# Altium schematic sheet Top.SchDoc
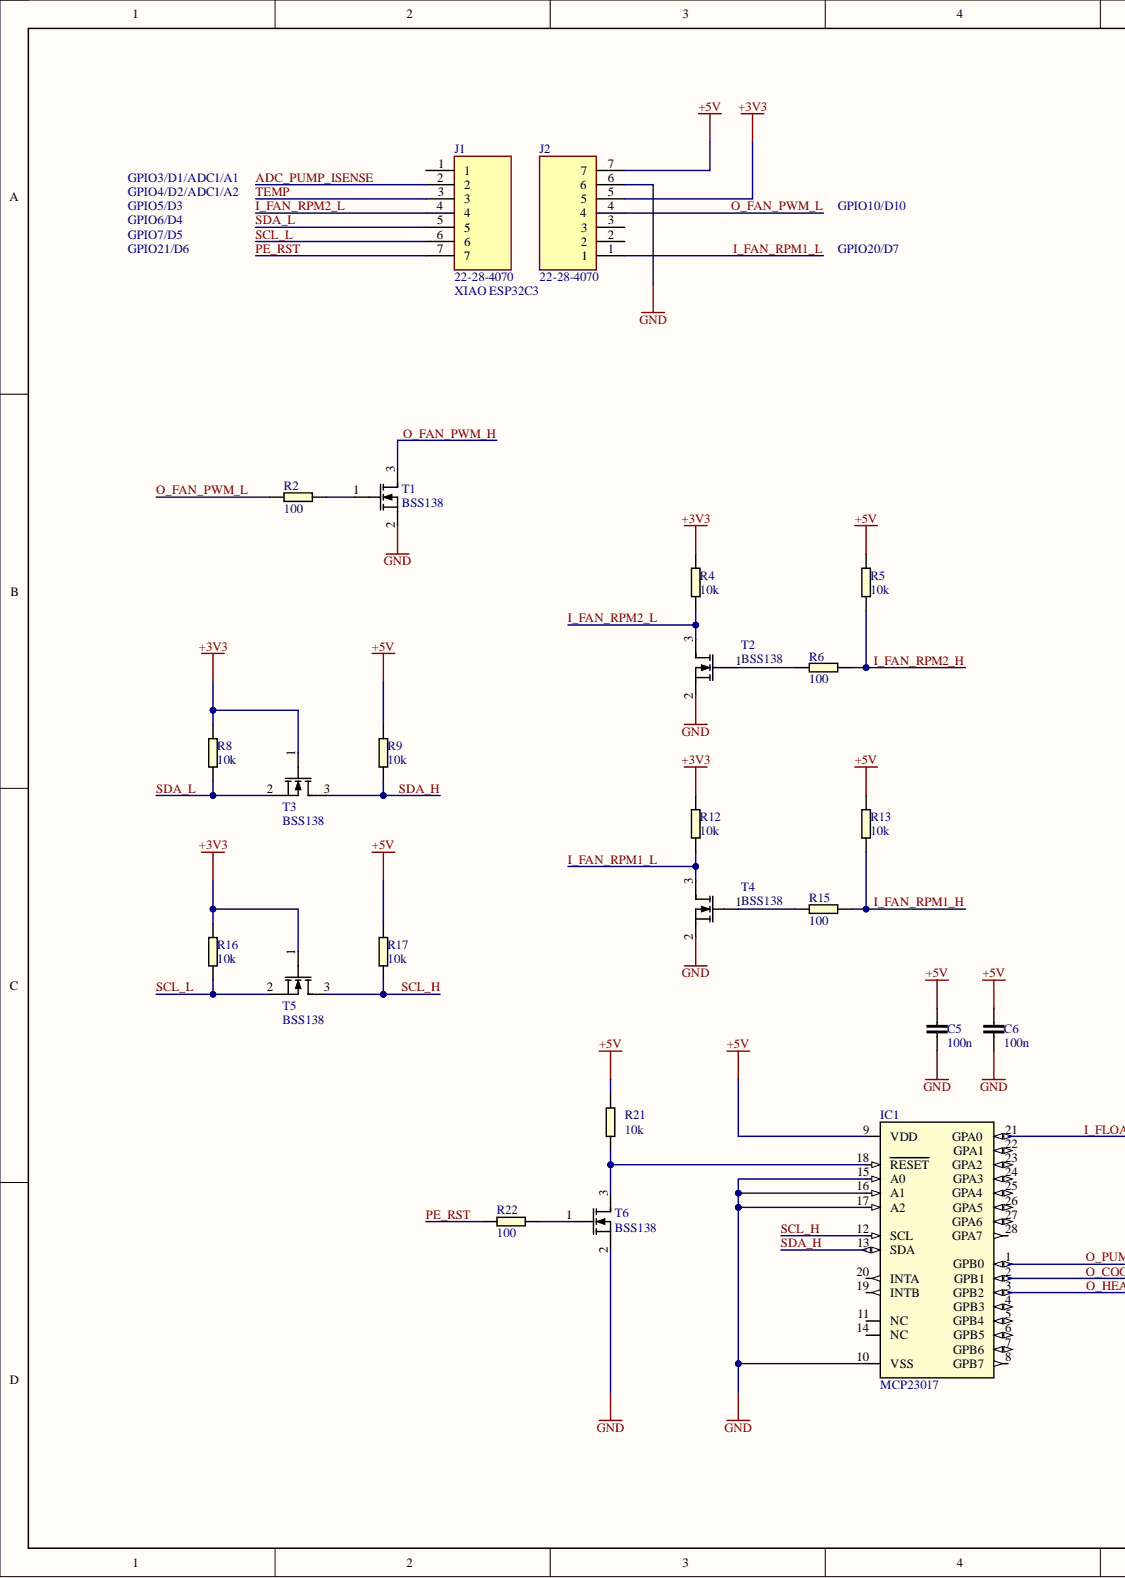
[diagram p0: Top.SchDoc, left]
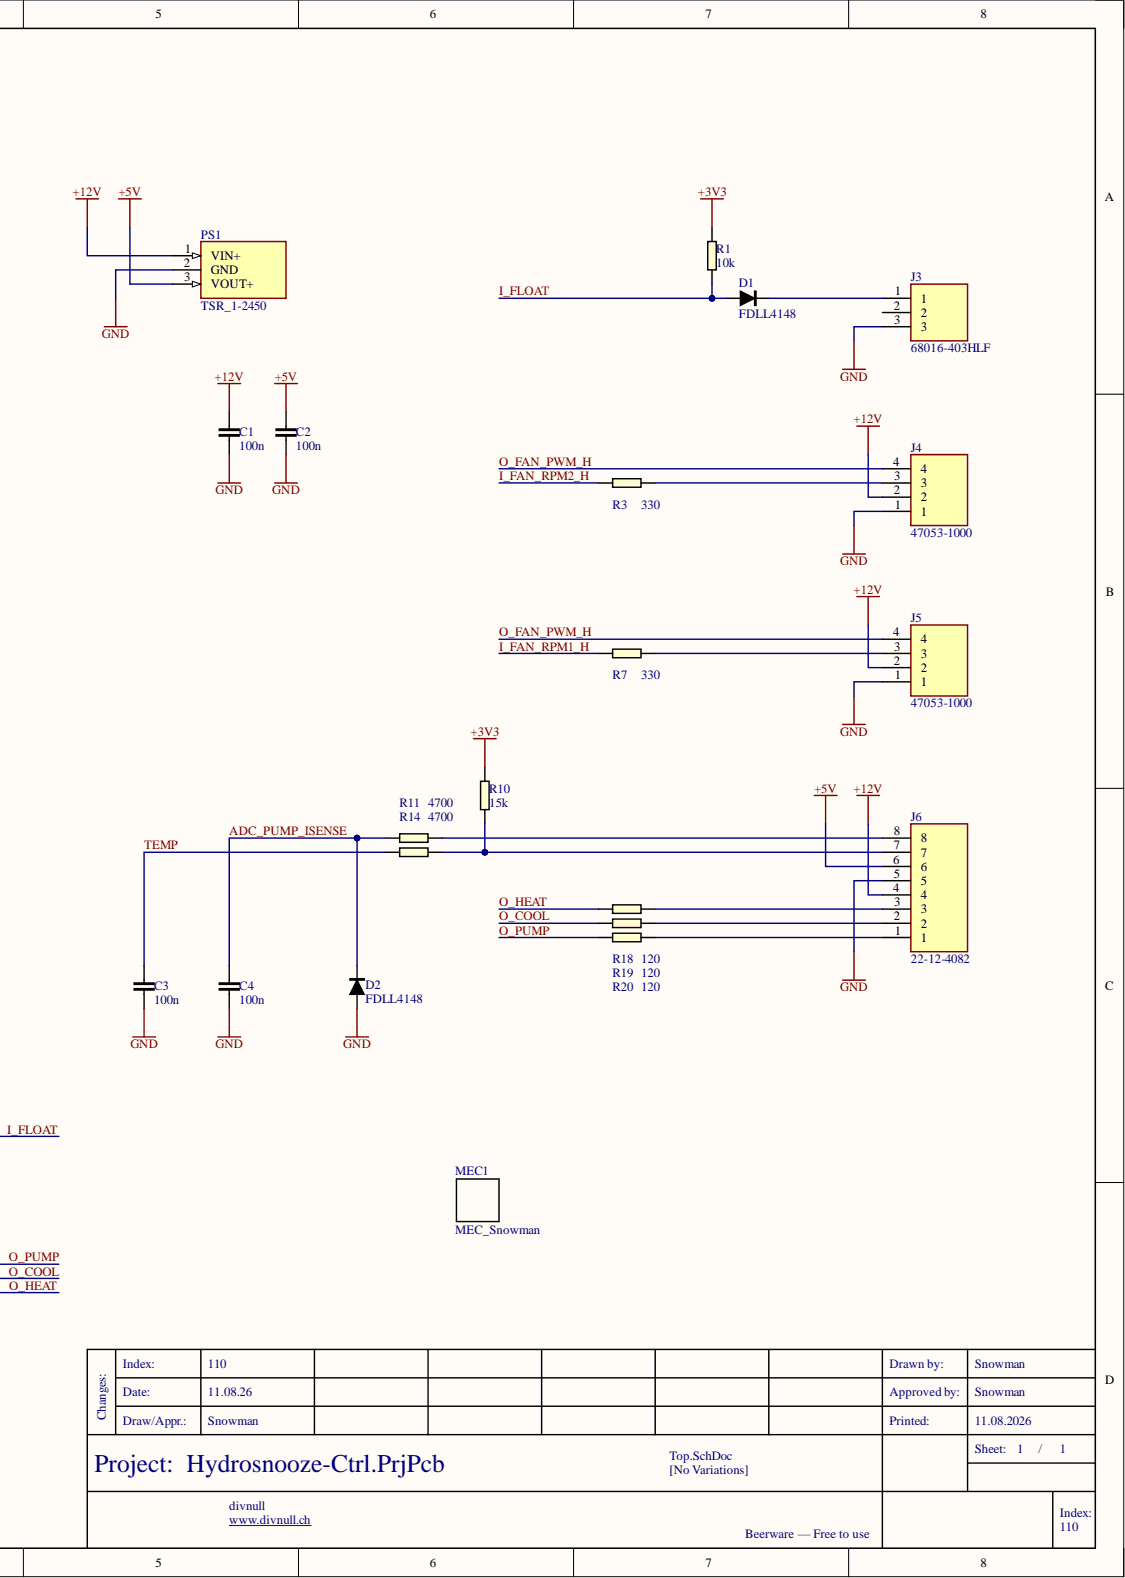
[diagram p1: Top.SchDoc, right]

## Parts
C1  100n  [CAP_0603]
C2  100n  [CAP_0603]
C3  100n  [CAP_0603]
C4  100n  [CAP_0603]
C5  100n  [CAP_0603]
C6  100n  [CAP_0603]
D1  FDLL4148  [D_SOD-80 MiniMELF]
D2  FDLL4148  [D_SOD-80 MiniMELF]
IC1  MCP23017  [IC_TSSOP-28-65]
J1  22-28-4070  [HDRV7W66P0X254_1X7_1778X249X863P]
J2  22-28-4070  [HDRV7W66P0X254_1X7_1778X249X863P]
J3  68016-403HLF  [HDRRA3W67P0X254_1X3_762X254X241P]
J4  47053-1000  [470531000]
J5  47053-1000  [470531000]
J6  22-12-4082  [22124082]
MEC1  MEC_Snowman  [Snowman - Silk]  (no BOM)
PS1  TSR_1-2450  [TSR-1-24120]
R1  10k  [RES_0603]
R2  100  [RES_0603]
R3  330  [RES_0603]
R4  10k  [RES_0603]
R5  10k  [RES_0603]
R6  100  [RES_0603]
R7  330  [RES_0603]
R8  10k  [RES_0603]
R9  10k  [RES_0603]
R10  15k  [RES_0603]
R11  4700  [RES_0603]
R12  10k  [RES_0603]
R13  10k  [RES_0603]
R14  4700  [RES_0603]
R15  100  [RES_0603]
R16  10k  [RES_0603]
R17  10k  [RES_0603]
R18  120  [RES_0603]
R19  120  [RES_0603]
R20  120  [RES_0603]
R21  10k  [RES_0603]  (no BOM)
R22  100  [RES_0603]
T1  BSS138  [IC_SOT-23]
T2  BSS138  [IC_SOT-23]
T3  BSS138  [IC_SOT-23]
T4  BSS138  [IC_SOT-23]
T5  BSS138  [IC_SOT-23]
T6  BSS138  [IC_SOT-23]

## Nets
+3V3: J2.5 R1.1 R4.1 R8.2 R10.1 R12.1 R16.2 T3.1 T5.1
+5V: C2.1 C5.1 C6.1 IC1.9(VDD) J2.7 J6.6 PS1.3 R5.1 R9.2 R13.1 R17.2 R21.2
+12V: C1.1 J4.2 J5.2 J6.4 PS1.1
GND: C1.2 C2.2 C3.2 C4.2 C5.2 C6.2 D2.A IC1.10(VSS) IC1.15(A0) IC1.16(A1) IC1.17(A2) J2.6 J3.3 J4.1 J5.1 J6.5 PS1.2 T1.2 T2.2 T4.2 T6.2
ADC_PUMP_ISENSE: C4.1 D2.K J1.2 R11.2
I_FAN_RPM1_H: R7.2 R13.2 R15.1
I_FAN_RPM1_L: J2.1 R12.2 T4.3
I_FAN_RPM2_H: R3.2 R5.2 R6.1
I_FAN_RPM2_L: J1.4 R4.2 T2.3
I_FLOAT: D1.A IC1.21(GPA0) R1.2
O_COOL: IC1.2(GPB1) R19.2
O_FAN_PWM_H: J4.4 J5.4 T1.3
O_FAN_PWM_L: J2.4 R2.2
O_HEAT: IC1.3(GPB2) R18.2
O_PUMP: IC1.1(GPB0) R20.2
PE_RST: J1.7 R22.2
SCL_H: IC1.12(SCL) R17.1 T5.3
SCL_L: J1.6 R16.1 T5.2
SDA_H: IC1.13(SDA) R9.1 T3.3
SDA_L: J1.5 R8.1 T3.2
TEMP: C3.1 J1.3 R14.2
NetD1_K: D1.K J3.1
NetIC1_18: IC1.18(RESET) R21.1 T6.3
NetJ4_3: J4.3 R3.1
NetJ5_3: J5.3 R7.1
NetJ6_1: J6.1 R20.1
NetJ6_2: J6.2 R19.1
NetJ6_3: J6.3 R18.1
NetJ6_7: J6.7 R10.2 R14.1
NetJ6_8: J6.8 R11.1
NetR2_1: R2.1 T1.1
NetR6_2: R6.2 T2.1
NetR15_2: R15.2 T4.1
NetR22_1: R22.1 T6.1

Not connected: IC1 4(GPB3) 5(GPB4) 6(GPB5) 7(GPB6) 8(GPB7) 11(NC) 14(NC) 19(INTB) 20(INTA) 22(GPA1) 23(GPA2) 24(GPA3) 25(GPA4) 26(GPA5) 27(GPA6) 28(GPA7); J1 1; J2 2 3; J3 2

## Library data of the parts
C1-C6 = C_CAP: Component Kind: Standard; Library Name: VR25A.SchLib
D1 D2 = D_FDLL4148: Diode; Component Kind: Standard; ComponentLink1Description: Datasheet; Library Name: VR25A.SchLib; Mouser: 512-FDLL4148; ComponentLink1URL: D:\Altium\A_LIB\Datasheets\FDLL4148.pdf
IC1 = IC_MCP23017-E: Component Kind: Standard; Library Name: VR25A.SchLib; Mouser: 579-MCP23017-E/SS
J1 J2 = 22-28-4070: Connector; Manufacturer_Name: Molex; Component Kind: Standard; Datasheet Link: https://www.molex.com/content/dam/molex/molex-dot-com/products/automated/en-us/salesdrawingpdf/423/42375/022284070_sd.pdf?inline; Height: 8.63mm; Library Name: VR25A.SchLib; Manufacturer_Part_Number: 22-28-4070; Mouser Part Number: 538-22-28-4070; Mouser Price/Stock: https://www.mouser.co.uk/ProductDetail/Molex/22-28-4070?qs=Bf40AlDLLsO6VcvIbJpSGw%3D%3D
J3 = 68016-403HLF: Connector; Manufacturer_Name: Amphenol; Component Kind: Standard; Datasheet Link: https://cdn.amphenol-icc.com/media/wysiwyg/files/drawing/68015.pdf; Height: 2.41mm; Library Name: VR25A.SchLib; Manufacturer_Part_Number: 68016-403HLF
J4 J5 = 47053-1000: Connector; Manufacturer_Name: Molex; Component Kind: Standard; Datasheet Link: http://www.molex.com/pdm_docs/sd/470531000_sd.pdf; Height: 8mm; Library Name: VR25A.SchLib; Manufacturer_Part_Number: 47053-1000; Mouser Part Number: 538-47053-1000; Mouser Price/Stock: https://www.mouser.co.uk/ProductDetail/Molex/47053-1000?qs=ph4zPCVRuvqoDX7hrEhxNA%3D%3D
J6 = 22-12-4082: Connector; Manufacturer_Name: Molex; Component Kind: Standard; Datasheet Link: https://www.molex.com/content/dam/molex/molex-dot-com/products/automated/en-us/salesdrawingpdf/739/7395/022124082_sd.pdf; Height: 6.05mm; Library Name: VR25A.SchLib; Manufacturer_Part_Number: 22-12-4082; Mouser Part Number: 538-22-12-4082; Mouser Price/Stock: https://www.mouser.co.uk/ProductDetail/Molex/22-12-4082?qs=K5bGVUuM57rYGyxxkMLFyw%3D%3D
PS1 = TSR_1-2450: Power Supply; Manufacturer_Name: Traco Power; Manufacturer_Part_Number: TSR 1-2450; Arrow Part Number: TSR 1-2450; Arrow Price/Stock: https://www.arrow.com/en/products/tsr1-2450/traco-electronic-ag?utm_currency=USD&region=europe; Component Kind: Standard; Datasheet Link: https://tracopower.com/tsr1-datasheet/; Library Name: VR25A.SchLib; Mouser Part Number: 495-TSR-1-2450; Mouser Price/Stock: https://www.mouser.co.uk/ProductDetail/TRACO-Power/TSR-1-2450?qs=ckJk83FOD0XFKqda0Mzkgw%3D%3D
R1-R22 = R_Res: Resistor; Library Name: VR25A.SchLib
  R1-R20 R22: Component Kind: Standard
T1-T6 = T_MOSFET-N_BSS138: N-CHANNEL ENHANCEMENT MODE MOSFET VDSmax: 50V, IDmax: 200mA; Component Kind: Standard; ComponentLink1Description: Datasheet; Library Name: VR25A.SchLib; Mouser Part Number: 621-BSS138W-F; ComponentLink1URL: D:\Altium\A_LIB\Datasheets\BSS138W.pdf
MEC1 = MEC_Snowman: Library Name: VR25A.SchLib
